# Altium schematic sheet ZTWL-DPKZQ-V2.1.SchDoc
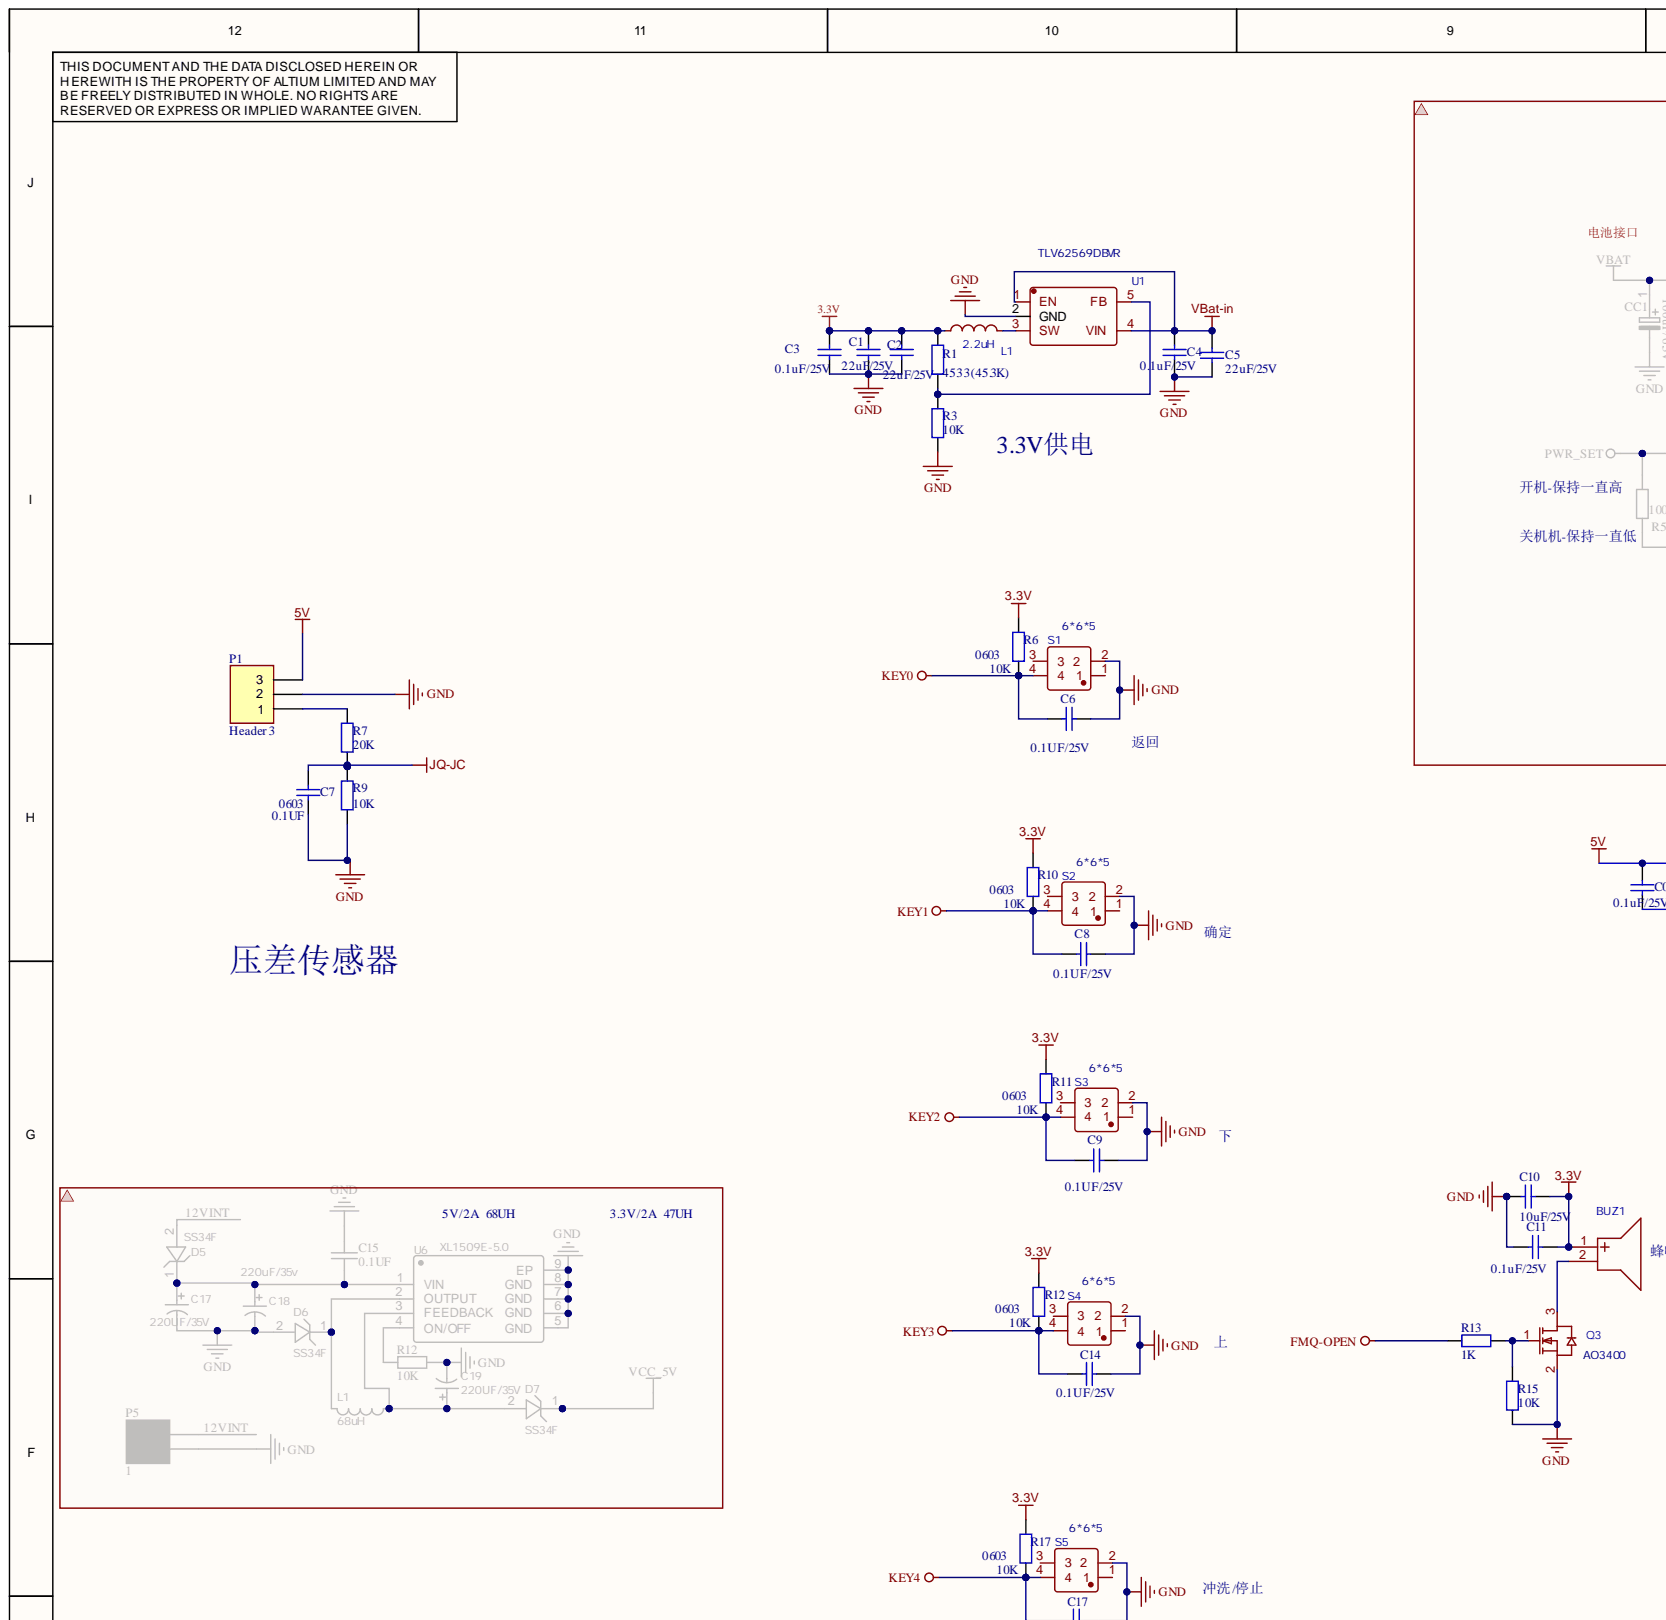
[diagram p0: ZTWL-DPKZQ-V2.1.SchDoc, top left]
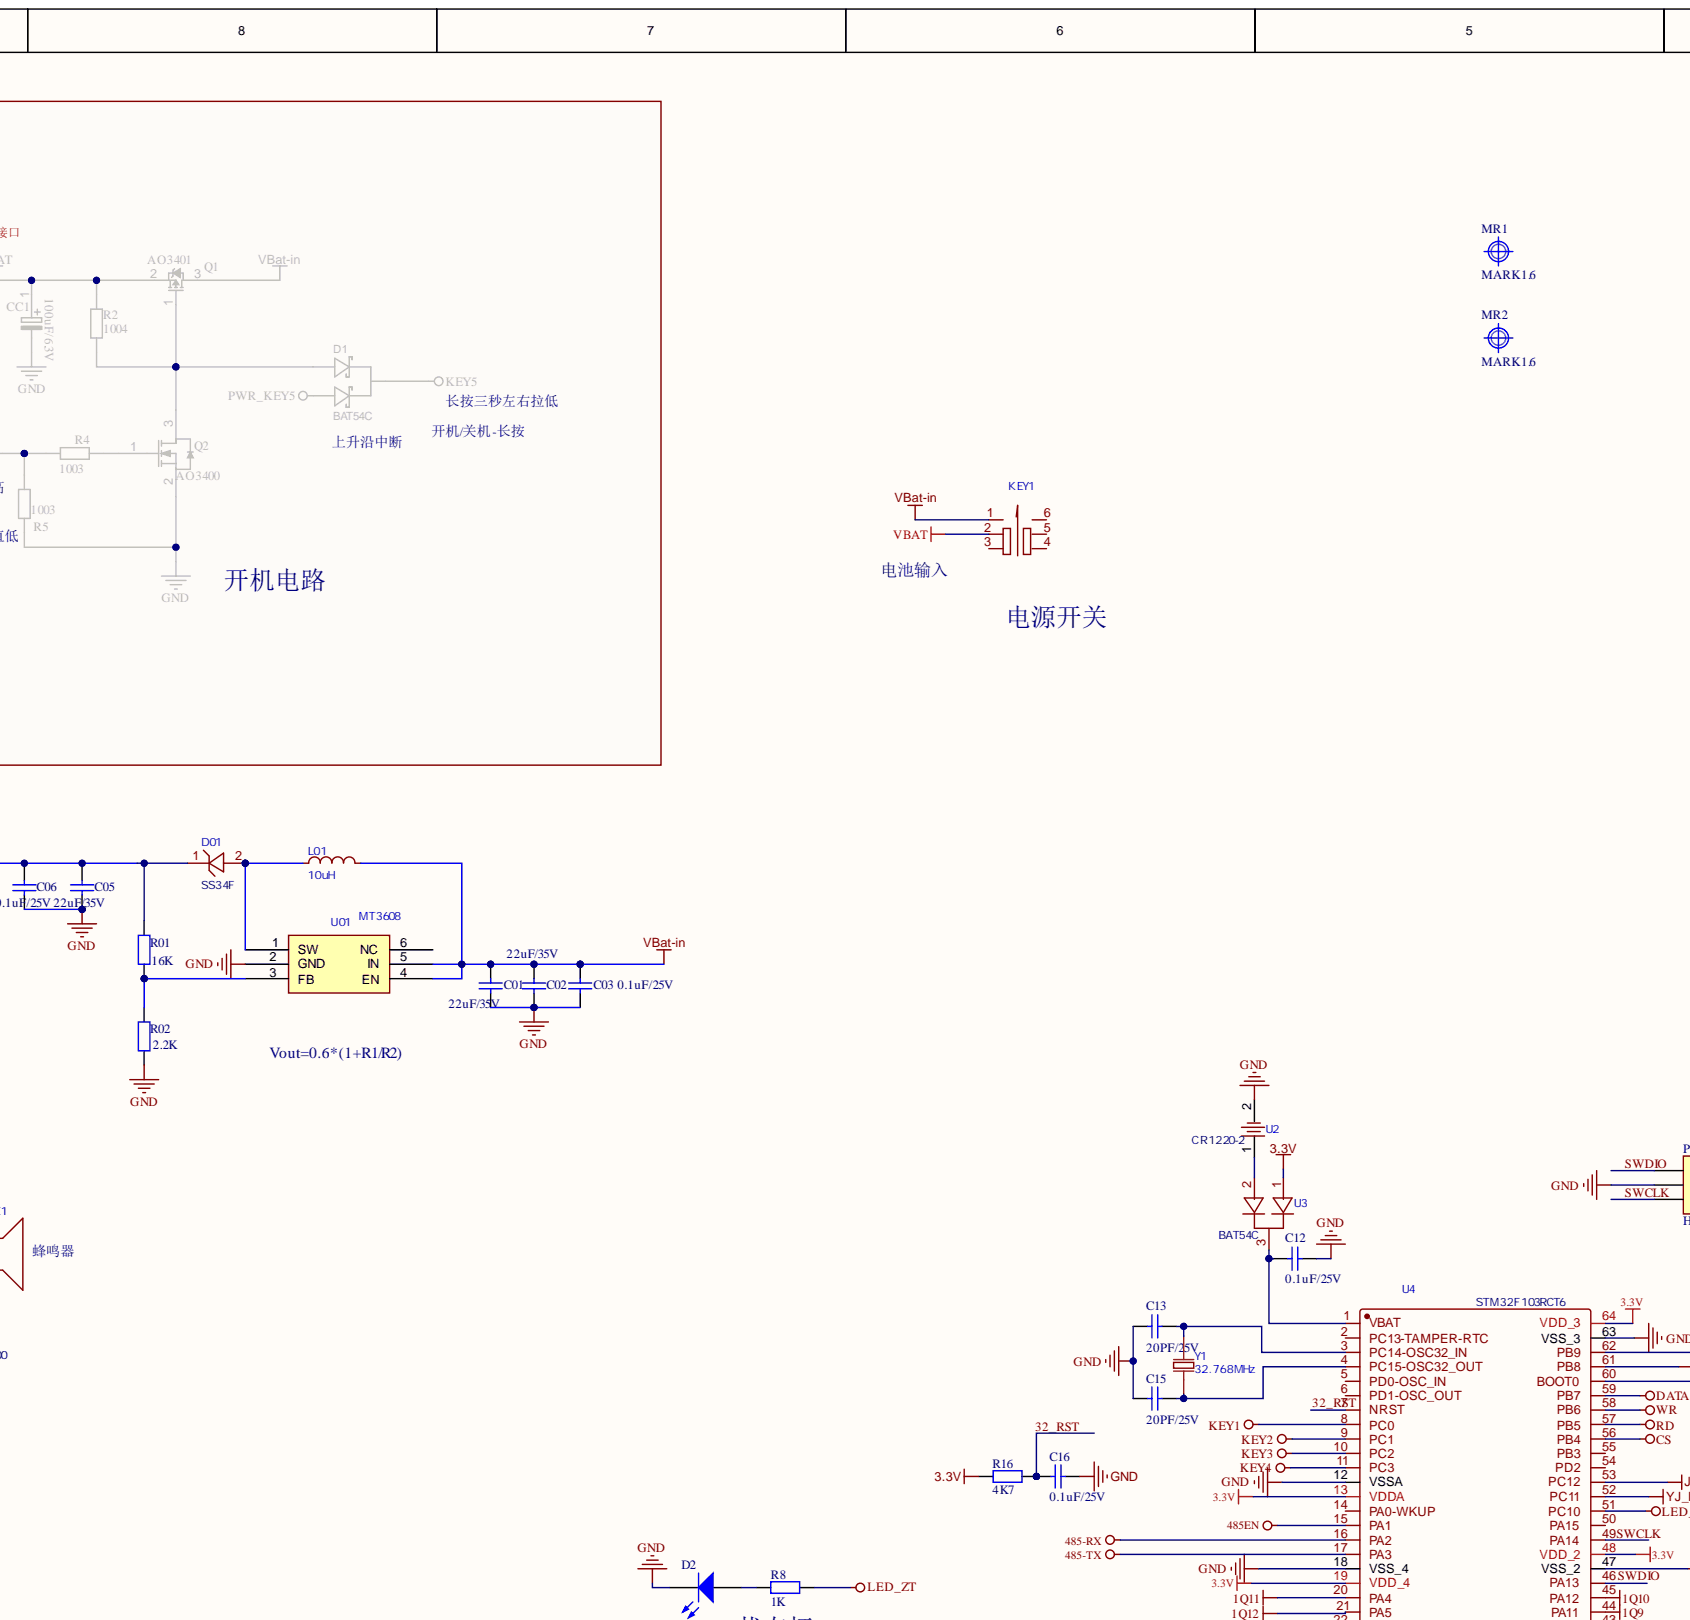
[diagram p1: ZTWL-DPKZQ-V2.1.SchDoc, top centre]
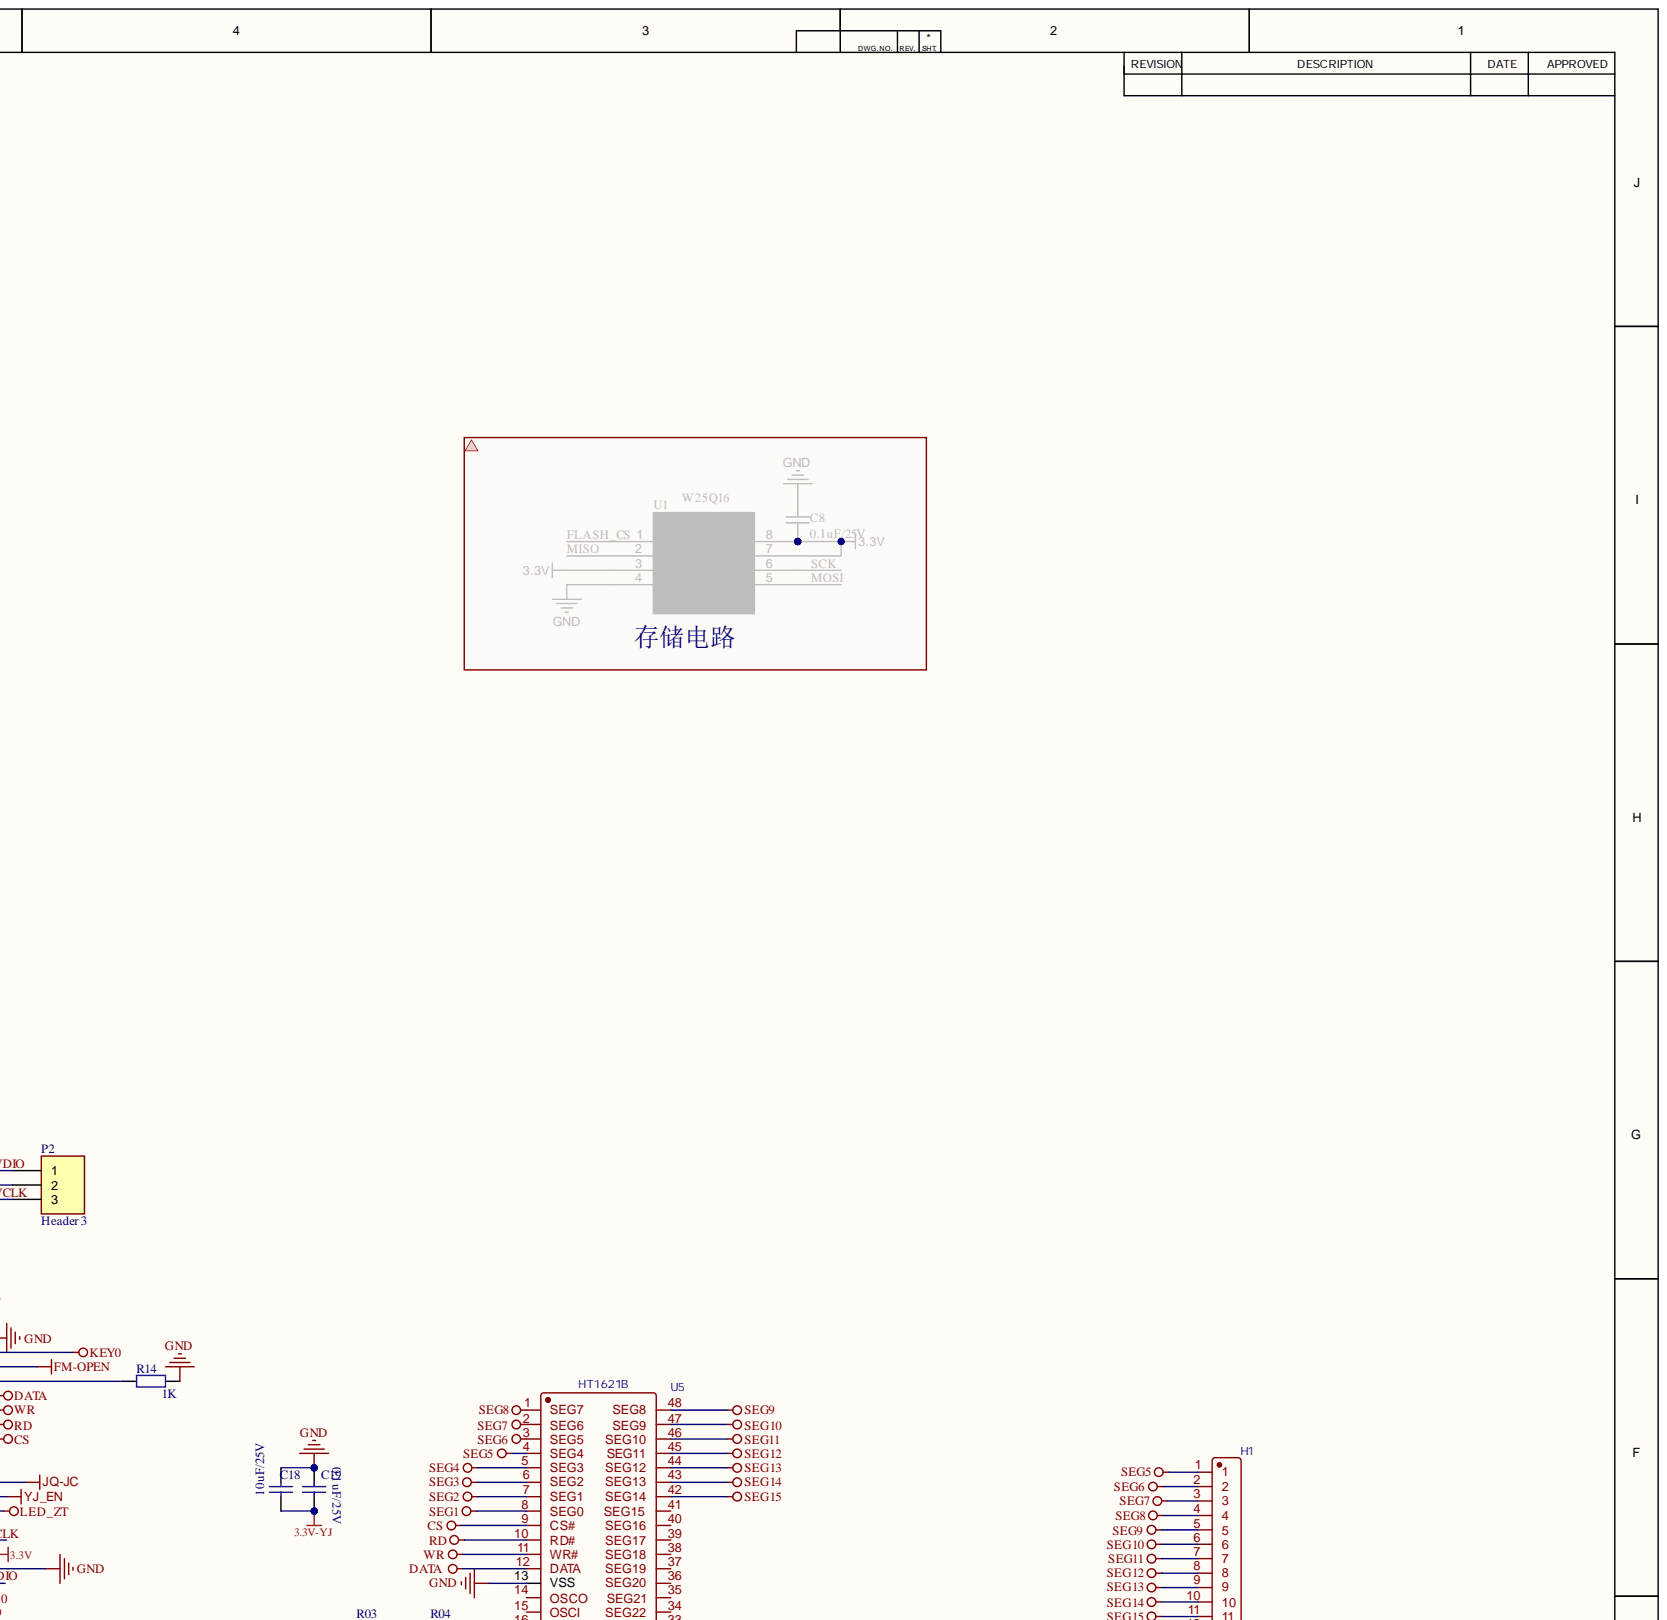
[diagram p2: ZTWL-DPKZQ-V2.1.SchDoc, top right]
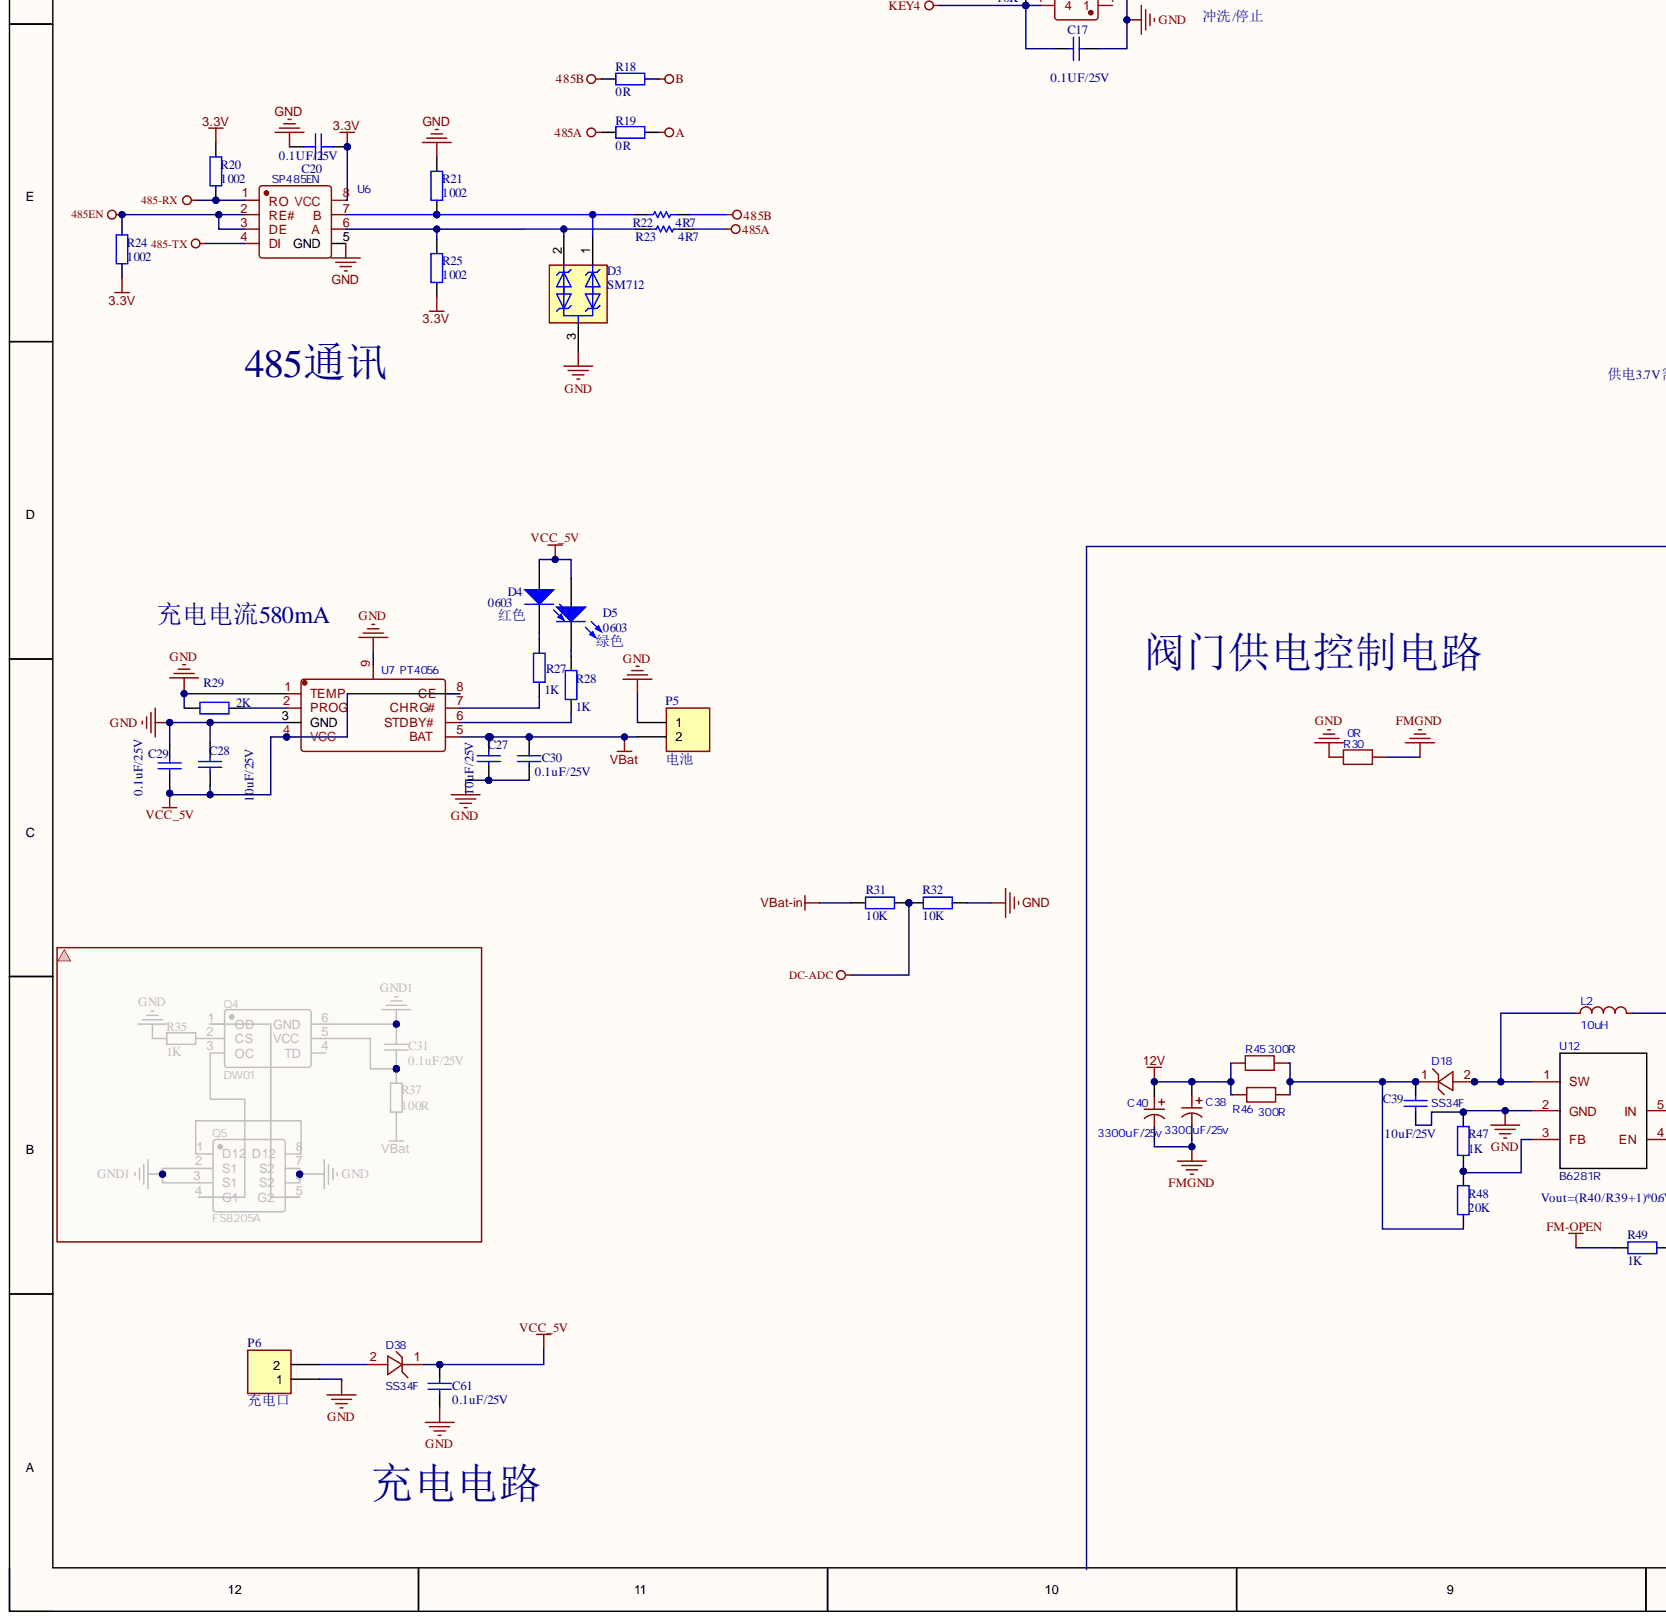
[diagram p3: ZTWL-DPKZQ-V2.1.SchDoc, bottom left]
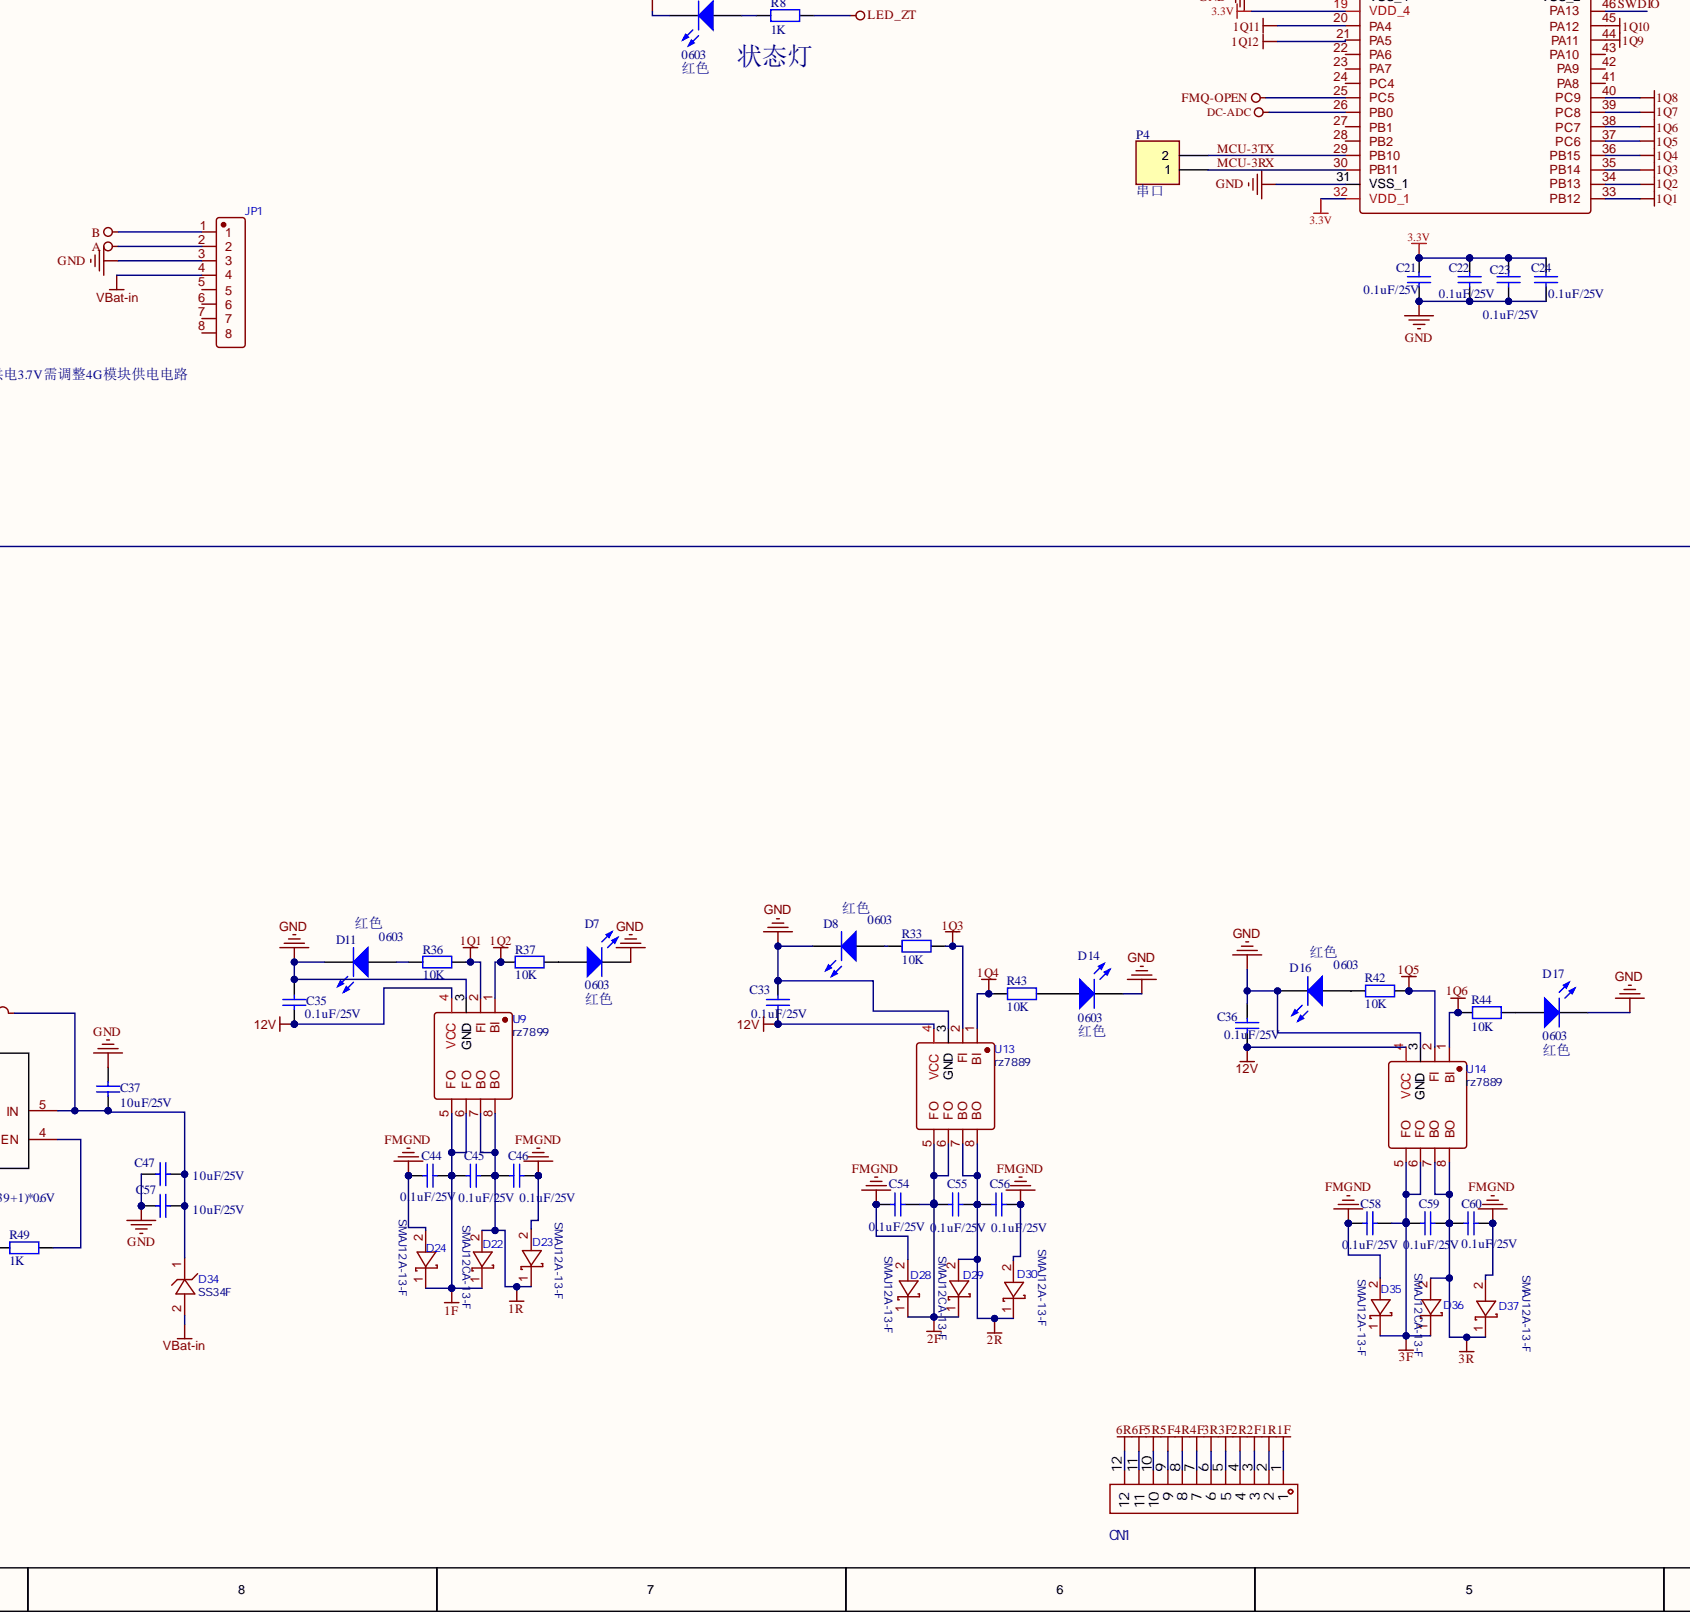
[diagram p4: ZTWL-DPKZQ-V2.1.SchDoc, bottom centre]
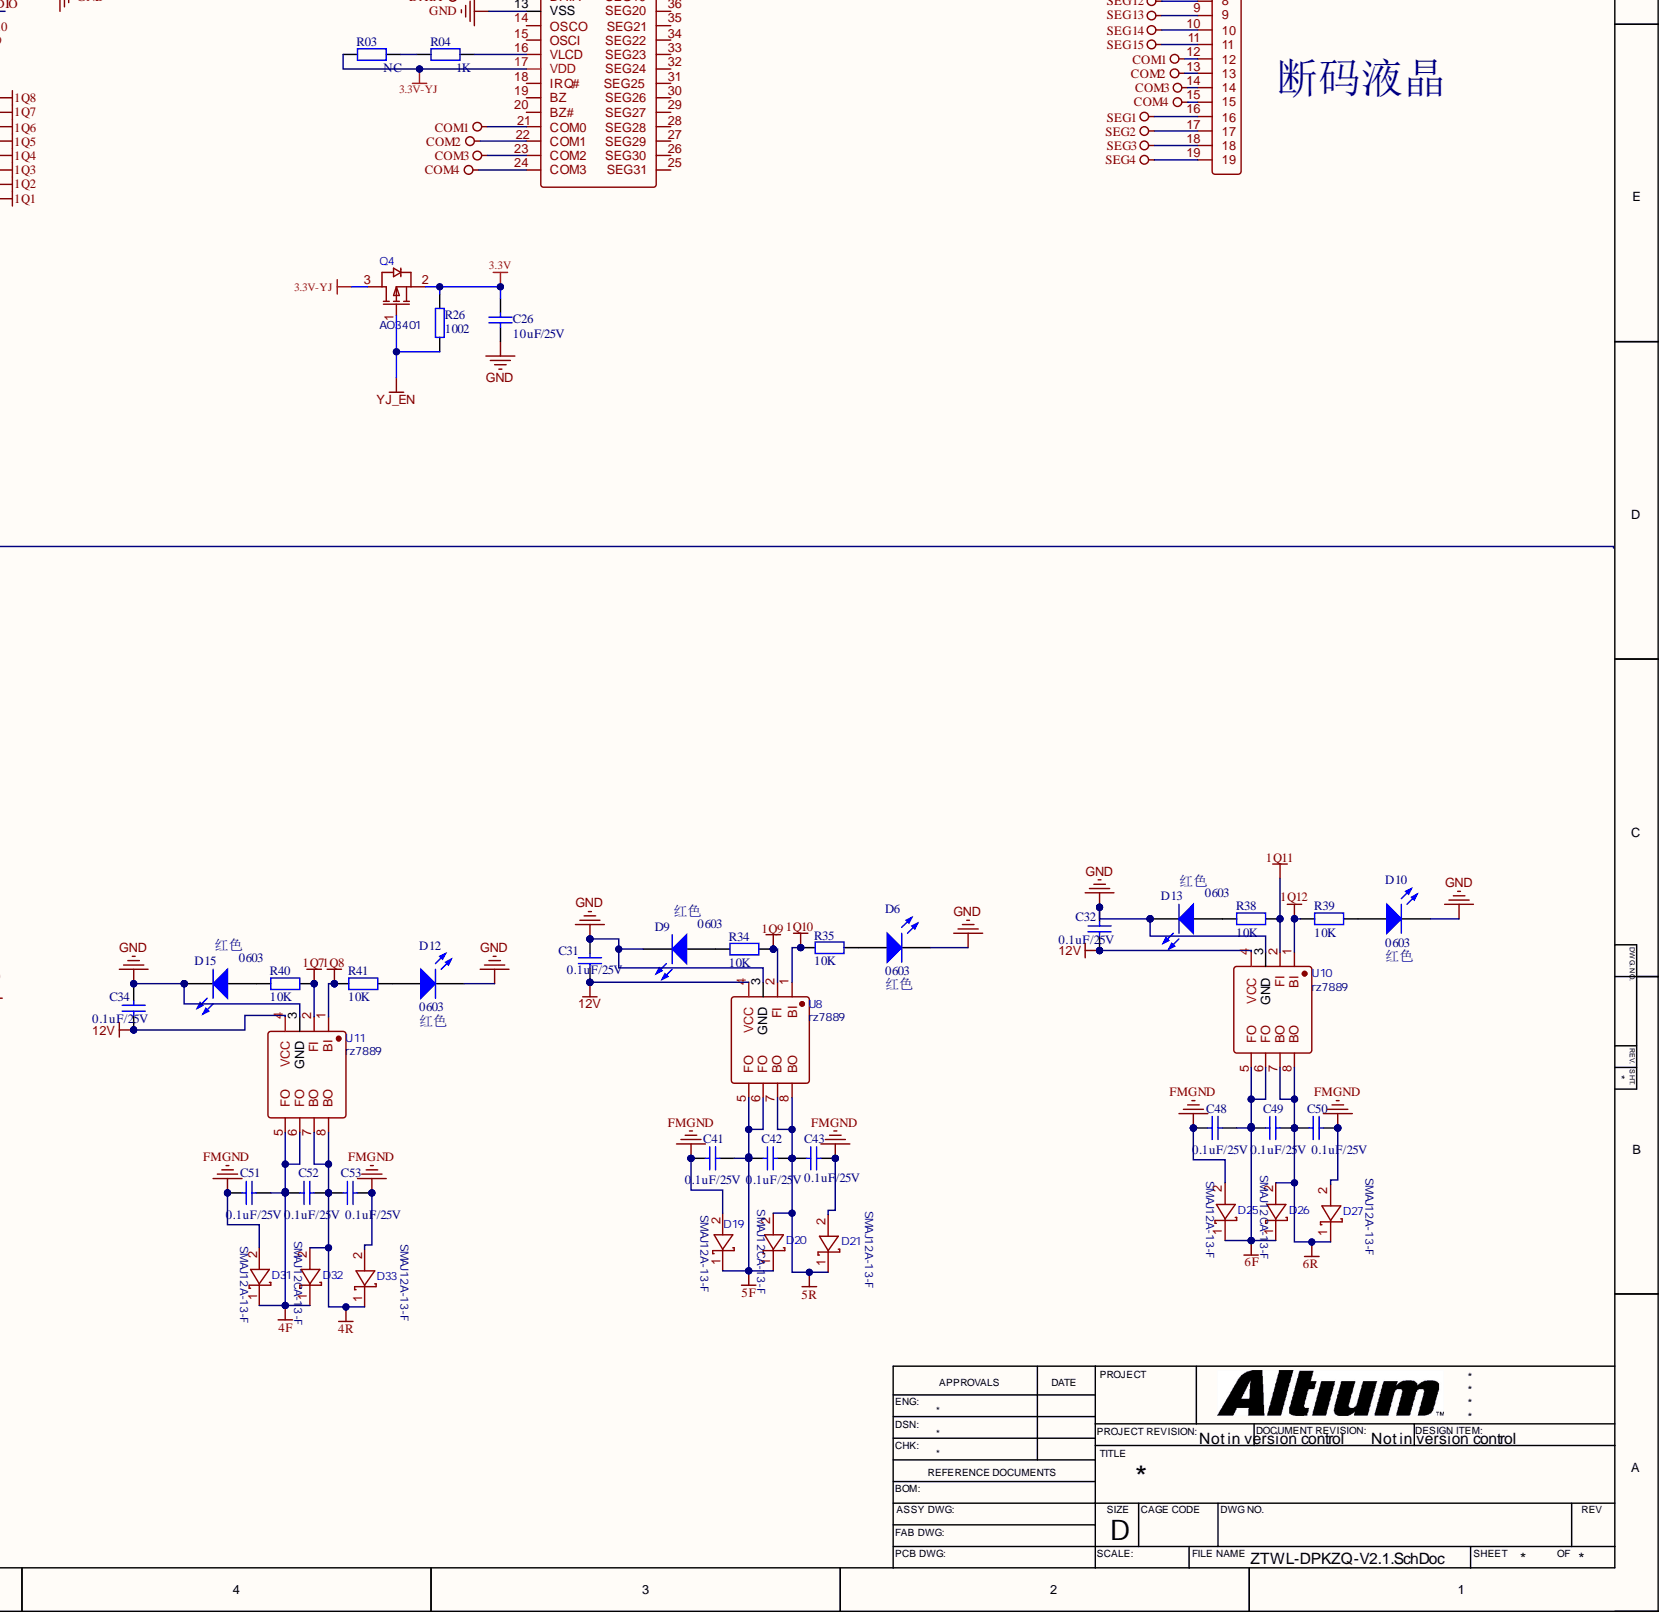
[diagram p5: ZTWL-DPKZQ-V2.1.SchDoc, bottom right]

## Parts
BUZ1  (no value)  [蜂鸣器-3V有源]
C1  22uF/25V  [C0805-C]
C01  22uF/35V  [0805C]
C2  22uF/25V  [C0805-C]
C02  22uF/35V  [0805C]
C3  0.1uF/25V  [R0603-R/C-Y]
C03  0.1uF/25V  [0603C]
C4  0.1uF/25V  [R0603-R/C-Y]
C5  22uF/25V  value 0.1uF/25V  [C0805-C]
C05  22uF/35V  [0805C]
C6  0.1UF/25V  [0603]
C06  0.1uF/25V  [0603C]
C7  value 0.1UF  [0603]  (hidden comment: Cap 0603)
C8  0.1UF/25V  [0603]
C9  0.1UF/25V  [0603]
C10  10uF/25V  [0805C]
C11  0.1uf/25V  [R0603-R/C-Y]
C12  0.1uF/25V  [R0603-R/C-Y]
C13  20PF/25V  [R0603-R/C-Y]
C14  0.1UF/25V  [0603]
C15  20PF/25V  [R0603-R/C-Y]
C16  0.1uf/25V  [R0603-R/C-Y]
C17  0.1UF/25V  [0603]
C18  10uF/25V  [0603C]
C19  0.1uF/25V  [0603C]
C20  0.1UF/25V  [R0603-R/C-Y]
C21  0.1uF/25V  [R0603-R/C-Y]
C22  0.1uF/25V  [R0603-R/C-Y]
C23  0.1uF/25V  [R0603-R/C-Y]
C24  0.1uF/25V  [R0603-R/C-Y]
C26  10uF/25V  [0603C]
C27  10uF/25V  [0805C]
C28  10uF/25V  [0805C]
C29  0.1uF/25V  [R0603-R/C-Y]
C30  0.1uF/25V  [0603C]
C31  0.1uF/25V  [0603C]
C32  0.1uF/25V  [0603C]
C33  0.1uF/25V  [0603C]
C34  0.1uF/25V  [0603C]
C35  0.1uF/25V  [0603C]
C36  0.1uF/25V  [0603C]
C37  10uF/25V  [0805C]
C38  3300uF/25v  [CAP-TH_BD10.0-P5.00-D1.0-FD]
C39  10uF/25V  [0805C]
C40  3300uF/25v  [CAP-TH_BD10.0-P5.00-D1.0-FD]
C41  0.1uF/25V  [0603C]
C42  0.1uF/25V  [0603C]
C43  0.1uF/25V  [0603C]
C44  0.1uF/25V  [0603C]
C45  0.1uF/25V  [0603C]
C46  0.1uF/25V  [0603C]
C47  10uF/25V  [0805C]
C48  0.1uF/25V  [0603C]
C49  0.1uF/25V  [0603C]
C50  0.1uF/25V  [0603C]
C51  0.1uF/25V  [0603C]
C52  0.1uF/25V  [0603C]
C53  0.1uF/25V  [0603C]
C54  0.1uF/25V  [0603C]
C55  0.1uF/25V  [0603C]
C56  0.1uF/25V  [0603C]
C57  10uF/25V  [0805C]
C58  0.1uF/25V  [0603C]
C59  0.1uF/25V  [0603C]
C60  0.1uF/25V  [0603C]
C61  0.1uF/25V  [R0603-R/C-Y]
CN1  KF2EDGR-5.08-12P  [螺钉式5.08-12P]  (value not drawn)
D01  SS34F  [SMA-SS34]
D2  value 红色  [0603]  (hidden comment: LED 0603)
D3  SM712  [SOT23]
D4  value 红色  [0603]  (hidden comment: LED 0603)
D5  value 绿色  [0603]  (hidden comment: LED 0603)
D6  value 红色  [0603]  (hidden comment: LED 0603)
D7  value 红色  [0603]  (hidden comment: LED 0603)
D8  value 红色  [0603]  (hidden comment: LED 0603)
D9  value 红色  [0603]  (hidden comment: LED 0603)
D10  value 红色  [0603]  (hidden comment: LED 0603)
D11  value 红色  [0603]  (hidden comment: LED 0603)
D12  value 红色  [0603]  (hidden comment: LED 0603)
D13  value 红色  [0603]  (hidden comment: LED 0603)
D14  value 红色  [0603]  (hidden comment: LED 0603)
D15  value 红色  [0603]  (hidden comment: LED 0603)
D16  value 红色  [0603]  (hidden comment: LED 0603)
D17  value 红色  [0603]  (hidden comment: LED 0603)
D18  SS34F  [SMA-SS34]
D19  SMAJ12A-13-F  [SMAJ12A-13-F-单向]
D20  SMAJ12CA-13-F  [SMAJ12CA-13-F-双向]
D21  SMAJ12A-13-F  [SMAJ12A-13-F-单向]
D22  SMAJ12CA-13-F  [SMAJ12CA-13-F-双向]
D23  SMAJ12A-13-F  [SMAJ12A-13-F-单向]
D24  SMAJ12A-13-F  [SMAJ12A-13-F-单向]
D25  SMAJ12A-13-F  [SMAJ12A-13-F-单向]
D26  SMAJ12CA-13-F  [SMAJ12CA-13-F-双向]
D27  SMAJ12A-13-F  [SMAJ12A-13-F-单向]
D28  SMAJ12A-13-F  [SMAJ12A-13-F-单向]
D29  SMAJ12CA-13-F  [SMAJ12CA-13-F-双向]
D30  SMAJ12A-13-F  [SMAJ12A-13-F-单向]
D31  SMAJ12A-13-F  [SMAJ12A-13-F-单向]
D32  SMAJ12CA-13-F  [SMAJ12CA-13-F-双向]
D33  SMAJ12A-13-F  [SMAJ12A-13-F-单向]
D34  SS34F  [SMA-SS34]
D35  SMAJ12A-13-F  [SMAJ12A-13-F-单向]
D36  SMAJ12CA-13-F  [SMAJ12CA-13-F-双向]
D37  SMAJ12A-13-F  [SMAJ12A-13-F-单向]
D38  SS34F  [SMA-SS34]
H1  (no value)  [2.54-断码液晶-19p]
JP1  (no value)  [4GDTU-外框]
KEY1  (no value)  [SW-TH-6P]
L1  2.2uH  [IND-SMD_L7.3-W6.6]
L01  10uH  [贴片电感-5020]
L2  10uH  [贴片电感-5020]
MR1  MARK1.6  [MYMark]
MR2  MARK1.6  [MYMark]
P1  Header 3  [HDR1X3]
P2  Header 3  [HDR1X3]
P4  串口  [HDR1X2-点]
P5  电池  [2.54-2P]
P6  充电口  [2.54-2P]
Q3  AO3400  [SOT-23-3_AO3400]
Q4  AO3401  [SOT-23-3_AO3400]
R1  453K  value 4533(45.3K)  [R0603-R/C-BY]
R01  16K  [0603]
R02  2.2K  [0603]
R3  10K  [R0603-R/C-BY]
R03  1K  value NC  [0603]
R04  1K  [0603]
R6  10k  [0603]
R7  10K  value 20K  [R0603-R/C-BY]
R8  1K  [0603]
R9  10K  [R0603-R/C-BY]
R10  10K  [0603]
R11  10K  [0603]
R12  10K  [0603]
R13  10K  value 1K  [0603]
R14  1K  [0603]
R15  10K  [0603]
R16  value 4K7  [R0603-R/C-BY]  (hidden comment: 4.7K)
R17  10K  [0603]
R18  0R  [R0603-R/C-BY]
R19  0R  [R0603-R/C-BY]
R20  1002  [R0603-R/C-BY]
R21  1002  [R0603-R/C-BY]
R22  4R7  [0603R]
R23  4R7  [0603R]
R24  1002  [R0603-R/C-BY]
R25  1002  [R0603-R/C-BY]
R26  1002  [0603R]
R27  1K  [0603]
R28  1K  [0603]
R29  2K  [0603]
R30  0R  [R1206]
R31  10K  [R0603-R/C-BY]
R32  10K  [R0603-R/C-BY]
R33  10K  [0603]
R34  10K  [0603]
R35  10K  [0603]
R36  10K  [0603]
R37  10K  [0603]
R38  10K  [0603]
R39  10K  [0603]
R40  10K  [0603]
R41  10K  [0603]
R42  10K  [0603]
R43  10K  [0603]
R44  10K  [0603]
R45  300R  [R1206]
R46  300R  [R1206]
R47  1K  [0603]
R48  1K  value 20K  [0603]
R49  1K  [0603]
S1  6*6*5  [贴片按键6*6*7.5]
S2  6*6*5  [贴片按键6*6*7.5]
S3  6*6*5  [贴片按键6*6*7.5]
S4  6*6*5  [贴片按键6*6*7.5]
S5  6*6*5  [贴片按键6*6*7.5]
U1  TLV62569DBVR  [SOT-23-5_L3.0-W1.7-P0.95-LS2.8-BR - duplicate]
U01  MT3608  [MT3608]
U2  CR1220-2  [BAT-SMD_CR1220-2 - duplicate1]
U3  BAT54C  [BAT45C]
U4  STM32F103RCT6  [LQFP-64_L10.0-W10.0-P0.50-LS12.0-BL]
U5  HT1621B  [SSOP-48]
U6  SP485EN  [SOIC-8_L5.3-W5.3-P1.27-LS8.0-BL]
U7  PT4056  [ESOP-8_L4.9-W3.9-P1.27-LS6.0-BL-EP]
U8  rz7889  [SOP-8-RZ7899]
U9  rz7899  [SOP-8-RZ7899]
U10  rz7889  [SOP-8-RZ7899]
U11  rz7889  [SOP-8-RZ7899]
U12  B6281R  [B6281]
U13  rz7889  [SOP-8-RZ7899]
U14  rz7889  [SOP-8-RZ7899]
Y1  32.768MHz  [OSC-TH_BD3.1-P0.8-D0.3]

## Nets
1F: C44.2 C45.1 CN1.1 D22.1 D24.1 U9.5(FO) U9.6(FO)
1Q1: R36.2 U4.33(PB12) U9.2(FI)
1Q2: R37.1 U4.34(PB13) U9.1
1Q3: R33.2 U4.35(PB14) U13.2
1Q4: R43.1 U4.36(PB15) U13.1(BI)
1Q5: R42.2 U4.37(PC6) U14.2(FI)
1Q6: R44.1 U4.38(PC7) U14.1(BI)
1Q7: R40.2 U4.39(PC8) U11.2(FI)
1Q8: R41.1 U4.40(PC9) U11.1(BI)
1Q9: R34.2 U4.44(PA11) U8.2(FI)
1Q10: R35.1 U4.45(PA12) U8.1(BI)
1Q11: R38.2 U4.20(PA4) U10.2(FI)
1Q12: R39.1 U4.21(PA5) U10.1(BI)
1R: C45.2 C46.1 CN1.2 D22.2 D23.1 U9.7(BO) U9.8
2F: C54.2 C55.1 CN1.3 D28.1 D29.1 U13.5 U13.6(FO)
2R: C55.2 C56.1 CN1.4 D29.2 D30.1 U13.7 U13.8(BO)
3.3V: BUZ1.1 C1.2 C2.2 C3.1 C10.2 C11.1 C20.1 C21.2 C22.2 C23.2 C24.2 C26.2 L1.1 Q4.2 R1.1 R6.2 R10.2 R11.2 R12.2 R16.2 R17.2 R20.1 R24.2 R25.2 R26.2 U3.1 U4.13(VDDA) U4.19(VDD_4) U4.32(VDD_1) U4.48(VDD_2) U4.64(VDD_3) U6.8(VCC)
3.3V-YJ: C18.2 C19.2 Q4.3 R03.2 U5.17(VDD)
3F: C58.2 C59.1 CN1.5 D35.1 D36.1 U14.5(FO) U14.6(FO)
3R: C59.2 C60.1 CN1.6 D36.2 D37.1 U14.7(BO) U14.8(BO)
4F: C51.2 C52.1 CN1.7 D31.1 D32.1 U11.5(FO) U11.6(FO)
4R: C52.2 C53.1 CN1.8 D32.2 D33.1 U11.7(BO) U11.8(BO)
5F: C41.2 C42.1 CN1.9 D19.1 D20.1 U8.5(FO) U8.6(FO)
5R: C42.2 C43.1 CN1.10 D20.2 D21.1 U8.7(BO) U8.8(BO)
5V: C05.1 C06.1 D01.1 P1.3 R01.1
6F: C48.2 C49.1 CN1.11 D25.1 D26.1 U10.5(FO) U10.6(FO)
6R: C49.2 C50.1 CN1.12 D26.2 D27.1 U10.7(BO) U10.8(BO)
12V: C31.1 C32.1 C33.1 C34.1 C35.1 C36.1 C38.1 C40.1 R45.2 R46.2 U8.4(VCC) U9.4(VCC) U10.4(VCC) U11.4(VCC) U13.4 U14.4(VCC)
485-RX: R20.2 U4.16(PA2) U6.1(RO)
485-TX: U4.17(PA3) U6.4(DI)
485A: R19.1 R23.1
485B: R18.1 R22.1
485EN: R24.1 U4.15(PA1) U6.2(RE#) U6.3(DE)
A: JP1.2 R19.2
B: JP1.1 R18.2
COM1: H1.12 U5.21(COM0)
COM2: H1.13 U5.22(COM1)
COM3: H1.14 U5.23(COM2)
COM4: H1.15 U5.24(COM3)
CS: U4.56(PB4) U5.9(CS#)
DATA: U4.59(PB7) U5.12(DATA)
DC-ADC: R31.1 R32.2 U4.26(PB0)
FM-OPEN: R49.2 U4.61(PB8)
FMGND: C38.2 C40.2 C41.1 C43.2 C44.1 C46.2 C48.1 C50.2 C51.1 C53.2 C54.1 C56.2 C58.1 C60.2 D19.2 D21.2 D23.2 D24.2 D25.2 D27.2 D28.2 D30.2 D31.2 D33.2 D35.2 D37.2 R30.1
FMQ-OPEN: R13.2 U4.25(PC5)
GND: C1.1 C01.2 C2.1 C02.2 C03.2 C3.2 C4.2 C5.1 C05.2 C6.2 C06.2 C7.1 C8.2 C9.2 C10.1 C11.2 C12.2 C13.2 C14.2 C15.2 C16.1 C17.2 C18.1 C19.1 C20.2 C21.1 C22.1 C23.1 C24.1 C26.1 C27.2 C28.1 C29.1 C30.2 C31.2 C32.2 C33.2 C34.2 C35.2 C36.2 C37.1 C39.1 C47.2 C57.2 C61.1 D2.2 D3.3 D6.2 D7.2 D8.2 D9.2 D10.2 D11.2 D12.2 D13.2 D14.2 D15.2 D16.2 D17.2 JP1.3 P1.2 P2.2 P5.1 P6.1 Q3.2 R02.1 R3.2 R9.2 R14.1 R15.2 R21.1 R29.2 R30.2 R32.1 R47.1 S1.2 S2.2 S3.2 S4.2 S5.2 U1.2(GND) U01.2(GND) U2.2 U4.12(VSSA) U4.18(VSS_4) U4.31(VSS_1) U4.47(VSS_2) U4.63(VSS_3) U5.13(VSS) U6.5(GND) U7.1(TEMP) U7.3(GND) U7.9 U8.3(GND) U9.3(GND) U10.3(GND) U11.3(GND) U12.2(GND) U13.3(GND) U14.3(GND)
JQ-JC: C7.2 R7.2 R9.1 U4.53(PC12)
KEY0: C6.1 R6.1 S1.4 U4.62(PB9)
KEY1: C8.1 R10.1 S2.4 U4.8(PC0)
KEY2: C9.1 R11.1 S3.4 U4.9(PC1)
KEY3: C14.1 R12.1 S4.4 U4.10(PC2)
KEY4: C17.1 R17.1 S5.4 U4.11(PC3)
LED_ZT: R8.2 U4.51(PC10)
RD: U4.57(PB5) U5.10(RD#)
SEG1: H1.16 U5.8(SEG0)
SEG2: H1.17 U5.7(SEG1)
SEG3: H1.18 U5.6(SEG2)
SEG4: H1.19 U5.5(SEG3)
SEG5: H1.1 U5.4(SEG4)
SEG6: H1.2 U5.3(SEG5)
SEG7: H1.3 U5.2(SEG6)
SEG8: H1.4 U5.1(SEG7)
SEG9: H1.5 U5.48(SEG8)
SEG10: H1.6 U5.47(SEG9)
SEG11: H1.7 U5.46(SEG10)
SEG12: H1.8 U5.45(SEG11)
SEG13: H1.9 U5.44(SEG12)
SEG14: H1.10 U5.43(SEG13)
SEG15: H1.11 U5.42(SEG14)
VBat: C27.1 C30.1 KEY1.2 P5.2 U7.5(BAT)
VBat-in: C01.1 C02.1 C03.1 C4.1 C5.2 D34.2 JP1.4 KEY1.1 L01.2 R31.2 U1.1(EN) U1.4(VIN) U01.4(EN) U01.5(IN)
VCC_5V: C28.2 C29.2 C61.2 D4.1 D5.1 D38.1 U7.4(VCC) U7.8(CE)
WR: U4.58(PB6) U5.11(WR#)
YJ_EN: Q4.1 R26.1 U4.52(PC11)
32_RST: C16.2 R16.1 U4.7(NRST)
MCU-3RX: P4.1 U4.30(PB11)
MCU-3TX: P4.2 U4.29(PB10)
SWCLK: P2.3 U4.49(PA14)
SWDIO: P2.1 U4.46(PA13)
NetBUZ1_2: BUZ1.2 Q3.3
NetC12_1: C12.1 U3.3 U4.1(VBAT)
NetC13_1: C13.1 U4.3(PC14-OSC32_IN) Y1.1
NetC15_1: C15.1 U4.4(PC15-OSC32_OUT) Y1.2
NetC37_2: C37.2 C47.1 C57.1 D34.1 L2.2 U12.5(IN)
NetC39_2: C39.2 D18.1 R45.1 R46.1 R48.1
NetD01_2: D01.2 L01.1 U01.1(SW)
NetD2_1: D2.1 R8.1
NetD3_1: D3.1 R21.2 R22.2 U6.7(B)
NetD3_2: D3.2 R23.2 R25.1 U6.6(A)
NetD4_2: D4.2 R27.1
NetD5_2: D5.2 R28.1
NetD6_1: D6.1 R35.2
NetD7_1: D7.1 R37.2
NetD8_1: D8.1 R33.1
NetD9_1: D9.1 R34.1
NetD10_1: D10.1 R39.2
NetD11_1: D11.1 R36.1
NetD12_1: D12.1 R41.2
NetD13_1: D13.1 R38.1
NetD14_1: D14.1 R43.2
NetD15_1: D15.1 R40.1
NetD16_1: D16.1 R42.1
NetD17_1: D17.1 R44.2
NetD18_2: D18.2 L2.1 U12.1(SW)
NetD38_2: D38.2 P6.2
NetL1_2: L1.2 U1.3(SW)
NetP1_1: P1.1 R7.1
NetQ3_1: Q3.1 R13.1 R15.1
NetR1_2: R01.2 R1.2 R02.2 R3.1 U01.3(FB) U1.5(FB)
NetR03_1: R03.1 R04.2
NetR04_1: R04.1 U5.16(VLCD)
NetR14_2: R14.2 U4.60(BOOT0)
NetR27_2: R27.2 U7.7(CHRG#)
NetR28_2: R28.2 U7.6(STDBY#)
NetR29_1: R29.1 U7.2(PROG)
NetR47_2: R47.2 R48.2 U12.3(FB)
NetR49_1: R49.1 U12.4(EN)
NetU2_1: U2.1 U3.2

Not connected: JP1 5 6 7 8; KEY1 3 4 5 6; S1 1 3; S2 1 3; S3 1 3; S4 1 3; S5 1 3; U01 6(NC); U4 2(PC13-TAMPER-RTC) 5(PD0-OSC_IN) 6(PD1-OSC_OUT) 14(PA0-WKUP) 22(PA6) 23(PA7) 24(PC4) 27(PB1) 28(PB2) 41(PA8) 42(PA9) 43(PA10) 50(PA15) 54(PD2) 55(PB3); U5 14(OSCO) 15(OSCI) 18(IRQ#) 19(BZ) 20(BZ#) 25(SEG31) 26(SEG30) 27(SEG29) 28(SEG28) 29(SEG27) 30(SEG26) 31(SEG25) 32(SEG24) 33(SEG23) 34(SEG22) 35(SEG21) 36(SEG20) 37(SEG19) 38(SEG18) 39(SEG17) 40(SEG16) 41(SEG15)
